# Altium schematic sheet IO_Circuits.SchDoc(IO_Circuits)
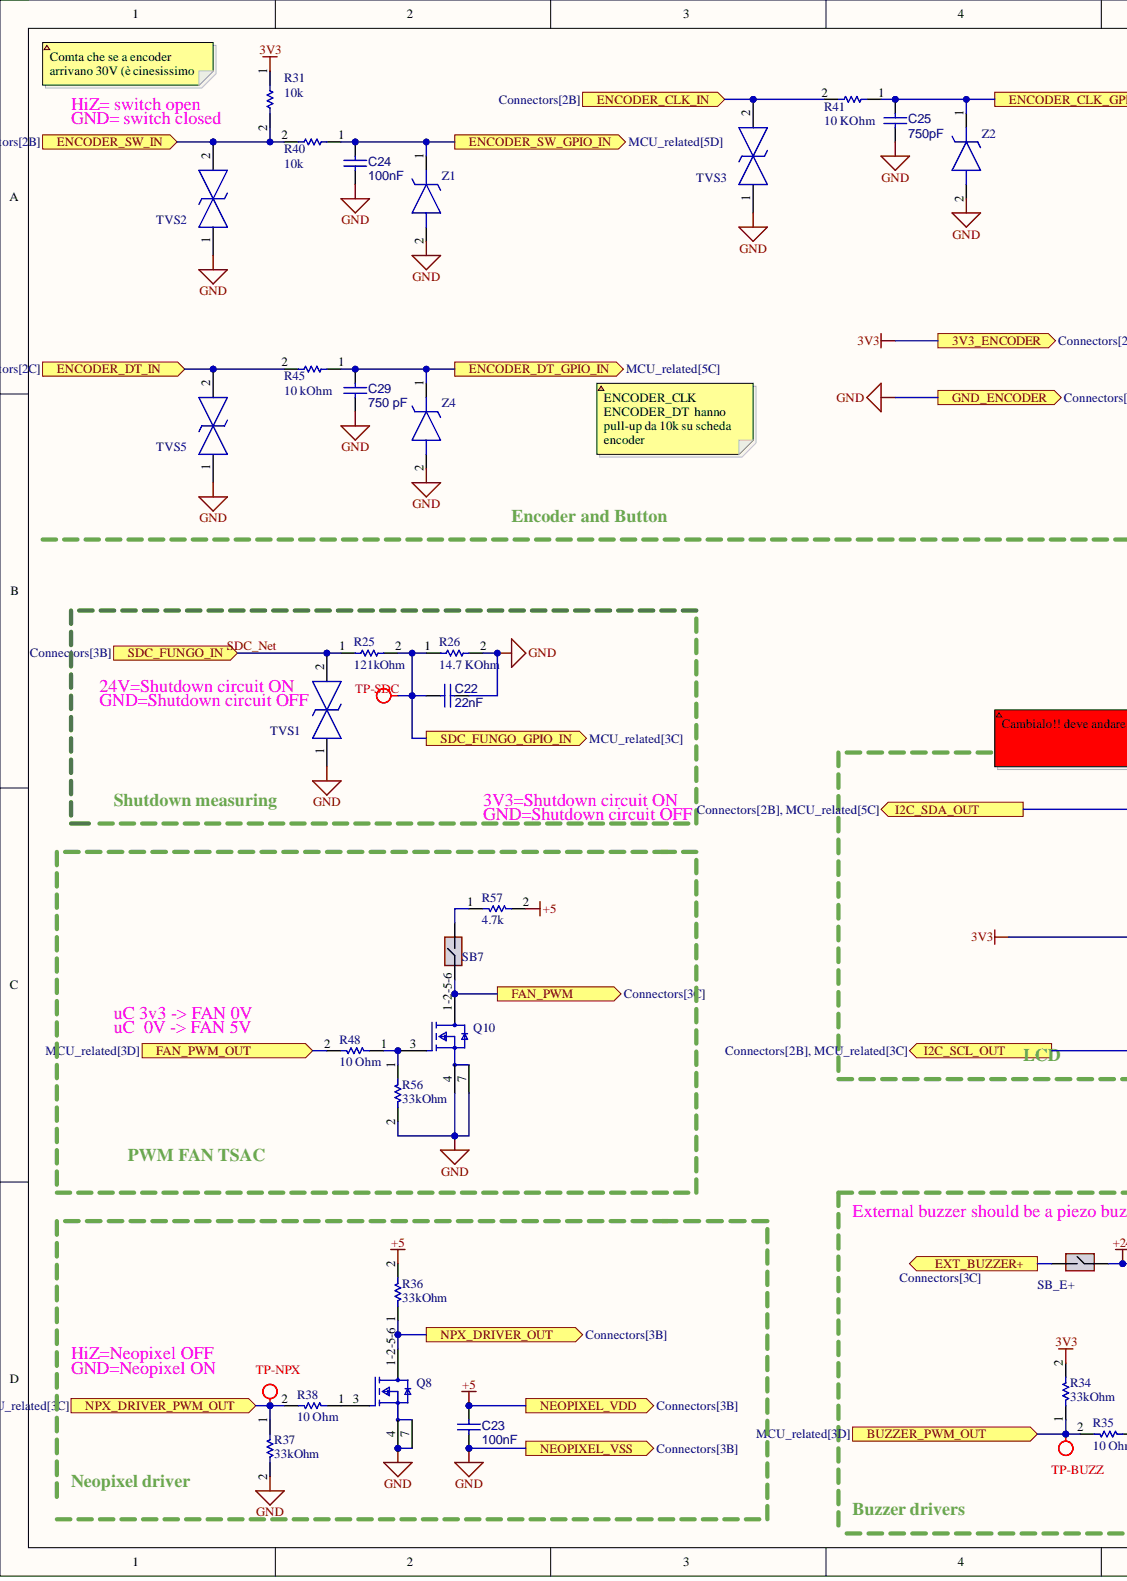
[diagram p0: IO_Circuits.SchDoc, left]
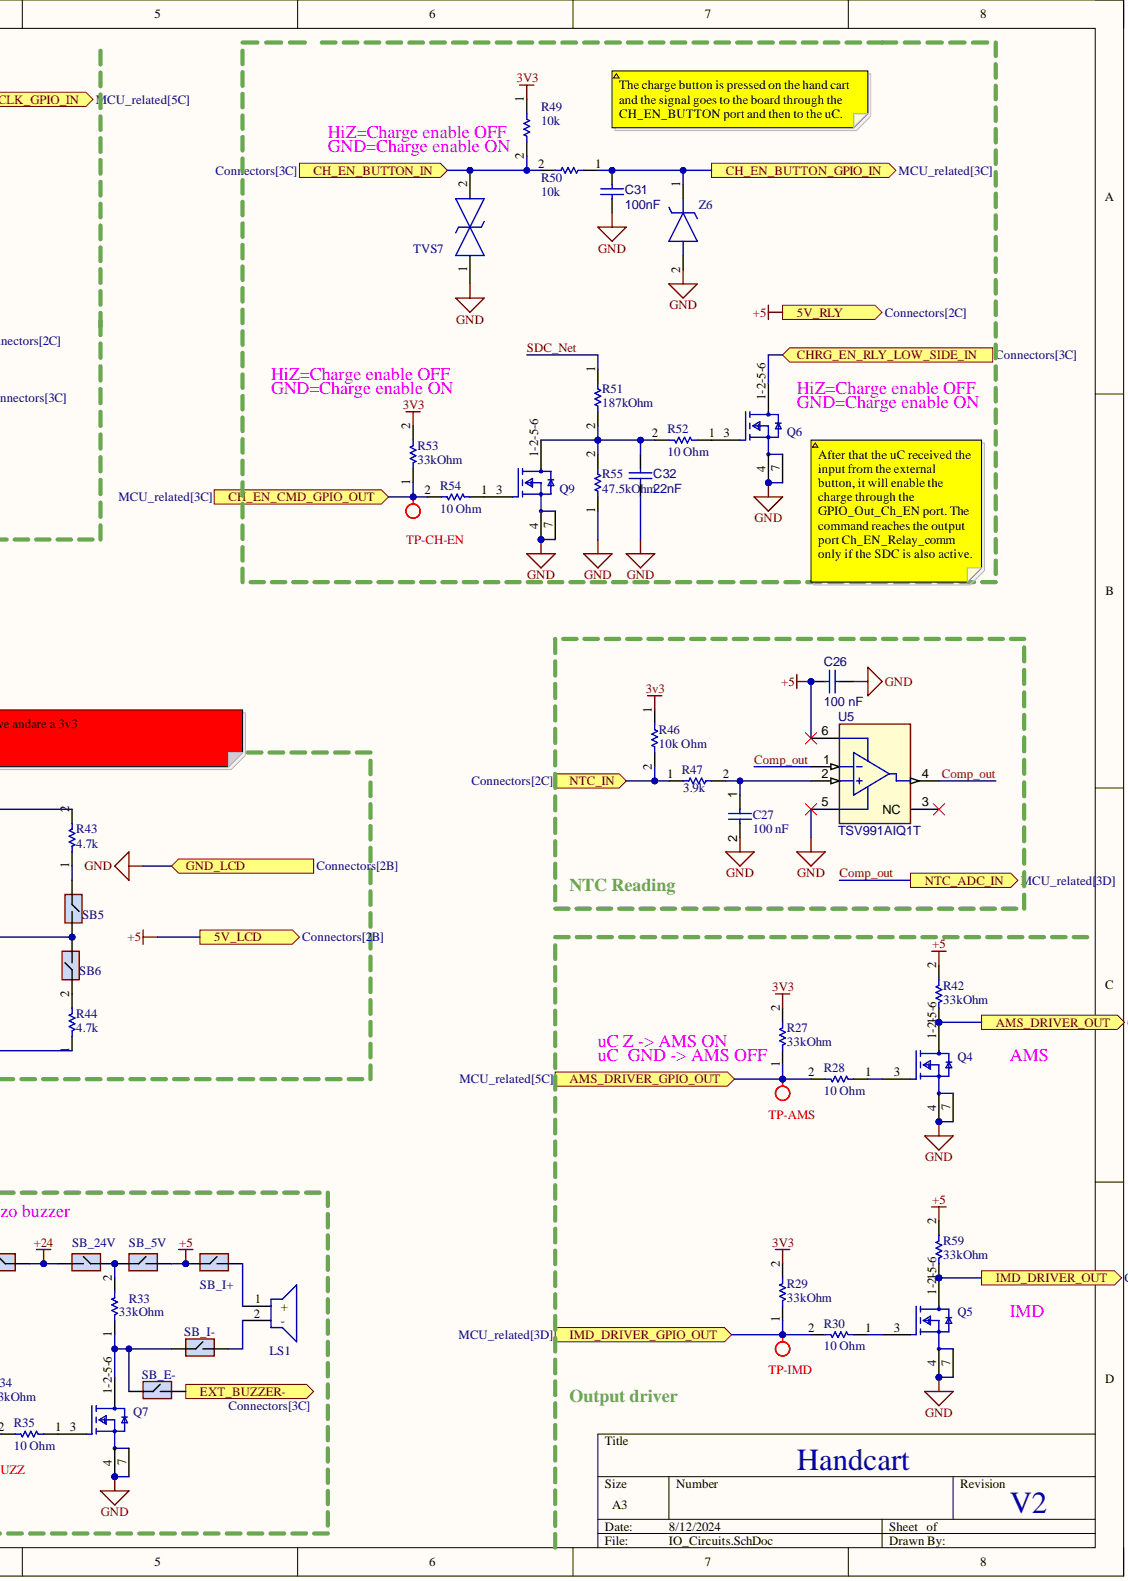
[diagram p1: IO_Circuits.SchDoc, right]

## Parts
C22  22nF
C23  100nF
C24  100nF
C25  750pF
C26  100 nF
C27  100 nF
C29  750 pF
C31  100nF
C32  22nF
LS1  AC-1205G-P4  (value not drawn)
Q4  SIA440DJ-T1-GE3  (value not drawn)
Q5  SIA440DJ-T1-GE3  (value not drawn)
Q6  SIA440DJ-T1-GE3  (value not drawn)
Q7  SIA440DJ-T1-GE3  (value not drawn)
Q8  SIA440DJ-T1-GE3  (value not drawn)
Q9  SIA440DJ-T1-GE3  (value not drawn)
Q10  SI1926SIA440DJ-T1-GE3DL-T1-GE3  (value not drawn)
R25  121kOhm
R26  14.7 KOhm
R27  33kOhm
R28  10 Ohm
R29  33kOhm
R30  10 Ohm
R31  10k
R33  33kOhm
R34  33kOhm
R35  10 Ohm
R36  33kOhm
R37  33kOhm
R38  10 Ohm
R40  10k
R41  10 KOhm
R42  33kOhm
R43  4.7k
R44  4.7k
R45  10 kOhm
R46  10k Ohm
R47  3.9k
R48  10 Ohm
R49  10k
R50  10k
R51  187kOhm
R52  10 Ohm
R53  33kOhm
R54  10 Ohm
R55  47.5kOhm
R56  33kOhm
R57  4.7k
R59  33kOhm
SB5  SolderBridge_0805  (value not drawn)  (no BOM)
SB6  SolderBridge_0805  (value not drawn)  (no BOM)
SB7  SolderBridge_0805  (value not drawn)  (no BOM)
SB_5V  SolderBridge_0805  (value not drawn)  (no BOM)
SB_24V  SolderBridge_0805  (value not drawn)  (no BOM)
SB_E+  SolderBridge_0805  (value not drawn)  (no BOM)
SB_E-  SolderBridge_0805  (value not drawn)  (no BOM)
SB_I+  SolderBridge_0805  (value not drawn)  (no BOM)
SB_I-  SolderBridge_0805  (value not drawn)  (no BOM)
TP-AMS  Test Point  (value not drawn)
TP-BUZZ  Test Point  (value not drawn)
TP-CH-EN  Test Point  (value not drawn)
TP-IMD  Test Point  (value not drawn)
TP-NPX  Test Point  (value not drawn)
TP-SDC  Test Point  (value not drawn)
TVS1  SMAJ30CA-TR  (value not drawn)
TVS2  SMAJ30CA-TR  (value not drawn)
TVS3  SMAJ30CA-TR  (value not drawn)
TVS5  SMAJ30CA-TR  (value not drawn)
TVS7  SMAJ30CA-TR  (value not drawn)
U5  TSV991AIQ1T
Z1  MMSZ5226C-HE3-08  (value not drawn)
Z2  MMSZ5226C-HE3-08  (value not drawn)
Z4  MMSZ5226C-HE3-08  (value not drawn)
Z6  MMSZ5226C-HE3-08  (value not drawn)

## Ports
3V3_ENCODER, 5V_LCD, 5V_RLY, AMS_DRIVER_GPIO_OUT, AMS_DRIVER_OUT, BUZZER_PWM_OUT, CH_EN_BUTTON_GPIO_IN, CH_EN_BUTTON_IN, CH_EN_CMD_GPIO_OUT, CHRG_EN_RLY_LOW_SIDE_IN, ENCODER_CLK_GPIO_IN, ENCODER_CLK_IN, ENCODER_DT_GPIO_IN, ENCODER_DT_IN, ENCODER_SW_GPIO_IN, ENCODER_SW_IN, EXT_BUZZER+, EXT_BUZZER-, FAN_PWM, FAN_PWM_OUT, GND_ENCODER, GND_LCD, I2C_SCL_OUT, I2C_SDA_OUT, IMD_DRIVER_GPIO_OUT, IMD_DRIVER_OUT, NEOPIXEL_VDD, NEOPIXEL_VSS, NPX_DRIVER_OUT, NPX_DRIVER_PWM_OUT, NTC_ADC_IN, NTC_IN, SDC_FUNGO_GPIO_IN, SDC_FUNGO_IN

## Nets
+24: SB_24V.2 SB_E+.1
3v3: R27.2 R29.2 R31.1 R34.2 R46.1 R49.1 R53.2 SB5.2 SB6.2 ; port 3V3_ENCODER
+5: C23.2 C26.2 R36.2 R42.2 R57.2 R59.2 SB_5V.2 SB_I+.1 U5.6 ; port 5V_LCD, port 5V_RLY, port NEOPIXEL_VDD
AMS_DRIVER_GPIO_OUT: R27.1 R28.2 TP-AMS.1 ; port AMS_DRIVER_GPIO_OUT
AMS_DRIVER_OUT: Q4.1-2-5-6 R42.1 ; port AMS_DRIVER_OUT
BUZZER_PWM_OUT: R34.1 R35.2 TP-BUZZ.1 ; port BUZZER_PWM_OUT
CH_EN_BUTTON_GPIO_IN: C31.2 R50.1 Z6.1 ; port CH_EN_BUTTON_GPIO_IN
CH_EN_BUTTON_IN: R49.2 R50.2 TVS7.2 ; port CH_EN_BUTTON_IN
CH_EN_CMD_GPIO_OUT: R53.1 R54.2 TP-CH-EN.1 ; port CH_EN_CMD_GPIO_OUT
CHRG_EN_RLY_LOW_SIDE_IN: Q6.1-2-5-6 ; port CHRG_EN_RLY_LOW_SIDE_IN
Comp_out: U5.1 U5.4 ; port NTC_ADC_IN
ENCODER_CLK_GPIO_IN: C25.2 R41.1 Z2.1 ; port ENCODER_CLK_GPIO_IN
ENCODER_CLK_IN: R41.2 TVS3.2 ; port ENCODER_CLK_IN
ENCODER_DT_GPIO_IN: C29.2 R45.1 Z4.1 ; port ENCODER_DT_GPIO_IN
ENCODER_DT_IN: R45.2 TVS5.2 ; port ENCODER_DT_IN
ENCODER_SW_GPIO_IN: C24.2 R40.1 Z1.1 ; port ENCODER_SW_GPIO_IN
ENCODER_SW_IN: R31.2 R40.2 TVS2.2 ; port ENCODER_SW_IN
FAN_PWM: Q10.1-2-5-6 SB7.1 ; port FAN_PWM
FAN_PWM_OUT: R48.2 ; port FAN_PWM_OUT
GND: C22.2 C23.1 C24.1 C25.1 C26.1 C27.2 C29.1 C31.1 C32.2 Q4.4 Q4.7 Q5.4 Q5.7 Q6.4 Q6.7 Q7.4 Q7.7 Q8.4 Q8.7 Q9.4 Q9.7 Q10.4 Q10.7 R26.2 R37.2 R55.1 R56.2 TVS1.1 TVS2.1 TVS3.1 TVS5.1 TVS7.1 U5.5 Z1.2 Z2.2 Z4.2 Z6.2 ; port GND_ENCODER, port GND_LCD, port NEOPIXEL_VSS
I2C_SCL_OUT: R44.1 ; port I2C_SCL_OUT
I2C_SDA_OUT: R43.2 ; port I2C_SDA_OUT
IMD_DRIVER_GPIO_OUT: R29.1 R30.2 TP-IMD.1 ; port IMD_DRIVER_GPIO_OUT
IMD_DRIVER_OUT: Q5.1-2-5-6 R59.1 ; port IMD_DRIVER_OUT
NPX_DRIVER_OUT: Q8.1-2-5-6 R36.1 ; port NPX_DRIVER_OUT
NPX_DRIVER_PWM_OUT: R37.1 R38.2 TP-NPX.1 ; port NPX_DRIVER_PWM_OUT
NTC_IN: R46.2 R47.1 ; port NTC_IN
SDC_FUNGO_GPIO_IN: C22.1 R25.2 R26.1 TP-SDC.1 ; port SDC_FUNGO_GPIO_IN
SDC_Net: R25.1 R51.1 TVS1.2 ; port SDC_FUNGO_IN
NetC27_1: C27.1 R47.2 U5.2
NetC32_1: C32.1 Q9.1-2-5-6 R51.2 R52.2 R55.2
NetLS1_1: LS1.1 SB_I+.2
NetLS1_2: LS1.2 SB_I-.2
NetQ4_3: Q4.3 R28.1
NetQ5_3: Q5.3 R30.1
NetQ6_3: Q6.3 R52.1
NetQ7_1-2-5-6: Q7.1-2-5-6 R33.1 SB_E-.1 SB_I-.1
NetQ7_3: Q7.3 R35.1
NetQ8_3: Q8.3 R38.1
NetQ9_3: Q9.3 R54.1
NetQ10_3: Q10.3 R48.1 R56.1
NetR33_2: R33.2 SB_5V.1 SB_24V.1
NetR43_1: R43.1 SB5.1
NetR44_2: R44.2 SB6.1
NetR57_1: R57.1 SB7.2
NetSB_E+_2: SB_E+.2
NetSB_E-_2: SB_E-.2

Not connected: U5 3(NC)

## Library data of the parts
C22 C32 = CMP-001-00085-5: VJ0805Y223JXACW1BC; Tech: SMD; Component Kind: Standard; ComponentLink1Description: Website; Package: 0805; Library Name: EMEA- Squadra Corse PoliTO-  Politecnico di Torino
C23 C24 C26 C31 = CMP-001-00085-5: VJ0805Y104KBABE31; Tech: SMD; Component Kind: Standard; ComponentLink1Description: Website; Package: 0805; Library Name: EMEA- Squadra Corse PoliTO-  Politecnico di Torino
C25 C29 = CMP-001-00085-5: VJ0805A751JXAMC; Tech: SMD; Component Kind: Standard; ComponentLink1Description: Website; Package: 0805; Library Name: EMEA- Squadra Corse PoliTO-  Politecnico di Torino
C27 = CMP-00009-1: VJ0805Y104MXATW1BC; Component Kind: Standard; Library Name: EMEA- Squadra Corse PoliTO-  Politecnico di Torino
LS1 = CMP-029-000023-1: Piezo Buzzers & Audio Indicators EM Buzzer 2400Hz 5VAC; Manufacturer_Name: AATC; Component Kind: Standard; Datasheet Link: https://www.aatc.tw/images/pdf/20200508bd19b.pdf; Design Item ID: AC-1205G-P4; Height: 8mm; Manufacturer_Part_Number: AC-1205G-P4; Source: SamacSys.SchLib; Library Name: EMEA- Squadra Corse PoliTO-  Politecnico di Torino
Q4-Q10 = CMP-02550-000315-1: MOSFET N-CH 40V 12A PPAK SC70-6; Drain to Source Resistance: 26mR; Element Configuration: Single; Fall Time: 5ns; Gate to Source Voltage (Vgs): 12V; Input Capacitance: 700pF; Max Power Dissipation: 19W; Number of Channels: 1; Polarity: N-CHANNEL; Rise Time: 32ns; Turn-Off Delay Time: 23ns; Turn-On Delay Time: 12ns; Component Kind: Standard; Continuous Drain Current (ID): 12A; Drain to Source Breakdown Voltage: 40V; Drain to Source Voltage (Vdss): 40V; Height: 0.75mm; Length: 2.05mm; Library Name: Altium Content Vault; Max Operating Temperature: 150°C; Min Operating Temperature: -55°C; Mount: Surface Mount; Number of Pins: 6; Package Quantity: 3000; Packaging: Tape and Reel; Radiation Hardening: No; Rds On Max: 26mR; RoHS Compliant: Yes; Series: TrenchFET®; Width: 2.05mm
R25 = CMP-009-00179-5: CRCW0603121KFKEA; Tech: SMD; Component Kind: Standard; ComponentLink1Description: Website; Package: 0603; Library Name: EMEA- Squadra Corse PoliTO-  Politecnico di Torino
R26 = CMP-009-00179-5: 71-CRCW0603-14.7K-E3; Tech: SMD; Component Kind: Standard; ComponentLink1Description: Website; Package: 0603; Library Name: EMEA- Squadra Corse PoliTO-  Politecnico di Torino
R27 R29 R33 R34 R36 R37 R42-R44 R53 R56 R57 R59 = CMP-009-00179-5: CRCW060333K0FKEAC; Tech: SMD; Component Kind: Standard; ComponentLink1Description: Website; Package: 0603; Library Name: EMEA- Squadra Corse PoliTO-  Politecnico di Torino
R28 R30 R35 R38 R48 R52 R54 = CMP-009-00179-5: CRCW060310R0FKEAHP; Tech: SMD; Component Kind: Standard; ComponentLink1Description: Website; Package: 0603; Library Name: EMEA- Squadra Corse PoliTO-  Politecnico di Torino
R31 R40 R41 R45 R46 R49 R50 = CMP-009-00179-5: RCS060310K0FKEA; Tech: SMD; Component Kind: Standard; ComponentLink1Description: Website; Package: 0603; Library Name: EMEA- Squadra Corse PoliTO-  Politecnico di Torino
R47 = CMP-00012-1: CRCW08053K90FKEAHP; Component Kind: Standard; Library Name: EMEA- Squadra Corse PoliTO-  Politecnico di Torino
R51 = CMP-009-00179-5: CRCW0603187KFKEA; Tech: SMD; Component Kind: Standard; ComponentLink1Description: Website; Package: 0603; Library Name: EMEA- Squadra Corse PoliTO-  Politecnico di Torino
R55 = CMP-009-00179-5: CRCW060347K5FKEA; Tech: SMD; Component Kind: Standard; ComponentLink1Description: Website; Package: 0603; Library Name: EMEA- Squadra Corse PoliTO-  Politecnico di Torino
SB5-SB7 SB_5V SB_24V = CMP-00006-5: 0805 Solder Bridge; Library Name: EMEA- Squadra Corse PoliTO-  Politecnico di Torino
SB_E+ = CMP-00006-5: SB_EXT_BUZZ+; Library Name: EMEA- Squadra Corse PoliTO-  Politecnico di Torino
SB_E- = CMP-00006-5: SB_EXT_BUZZ-; Library Name: EMEA- Squadra Corse PoliTO-  Politecnico di Torino
SB_I+ = CMP-00006-5: SB_INT_BUZZ+; Library Name: EMEA- Squadra Corse PoliTO-  Politecnico di Torino
SB_I- = CMP-00006-5: SB_INT_BUZZ-; Library Name: EMEA- Squadra Corse PoliTO-  Politecnico di Torino
TP-AMS TP-BUZZ TP-CH-EN TP-IMD TP-NPX TP-SDC = CMP-002-00071-2: Component Kind: Standard; Description: Test Point; Library Name: EMEA- Squadra Corse PoliTO-  Politecnico di Torino
TVS1-TVS3 TVS5 TVS7 = CMP-004-000005-1: TVS Diode Bi-Directional SMAJ30CA-TR 64.3V; Manufacturer_Name: STMicroelectronics; Arrow Part Number: SMAJ30CA-TR; Arrow Price/Stock: https://www.arrow.com/en/products/smaj30ca-tr/stmicroelectronics?region=nac; Component Kind: Standard; Datasheet Link: https://www.st.com/resource/en/datasheet/smaj10ca.pdf; Design Item ID: SMAJ30CA-TR; Height: 2.65mm; Manufacturer_Part_Number: SMAJ30CA-TR; Mouser Part Number: 511-SMAJ30CA-TR; Mouser Price/Stock: https://www.mouser.co.uk/ProductDetail/STMicroelectronics/SMAJ30CA-TR?qs=0TJjK1waviDY0EkLaKH12g%3D%3D; Source: SamacSys.SchLib; Library Name: EMEA- Squadra Corse PoliTO-  Politecnico di Torino
U5 = CMP-014-00000-3: Rail-to-rail Input / Output GBP Operational Amplifier, 20 MHz, -40 to 125 degC, 6-Pin DFN, RoHS, Tape and Reel; Channels: 2; Component Kind: Standard; Max Operating Temperature: 125°C; Min Operating Temperature: -40°C; Pins: 6; Library Name: EMEA- Squadra Corse PoliTO-  Politecnico di Torino
Z1 Z2 Z4 Z6 = CMP-011-000001-1: Zener Diodes 3.3 Volt 0.5W 2%; Manufacturer_Name: Vishay; Arrow Part Number: MMSZ5226C-HE3-08; Arrow Price/Stock: https://www.arrow.com/en/products/mmsz5226c-he3-08/vishay?region=nac; Component Kind: Standard; Datasheet Link: https://www.arrow.com/en/products/mmsz5226c-he3-08/vishay; Design Item ID: MMSZ5226C-HE3-08; Height: 1.35mm; Manufacturer_Part_Number: MMSZ5226C-HE3-08; Mouser Part Number: 78-MMSZ5226C-HE3-08; Mouser Price/Stock: https://www.mouser.co.uk/ProductDetail/Vishay-Semiconductors/MMSZ5226C-HE3-08?qs=ESFF34UzhwgdfTXz%2Fhjhlg%3D%3D; Source: SamacSys.SchLib; Library Name: EMEA- Squadra Corse PoliTO-  Politecnico di Torino
